# Altium schematic sheet Input_and_MUX.SchDoc
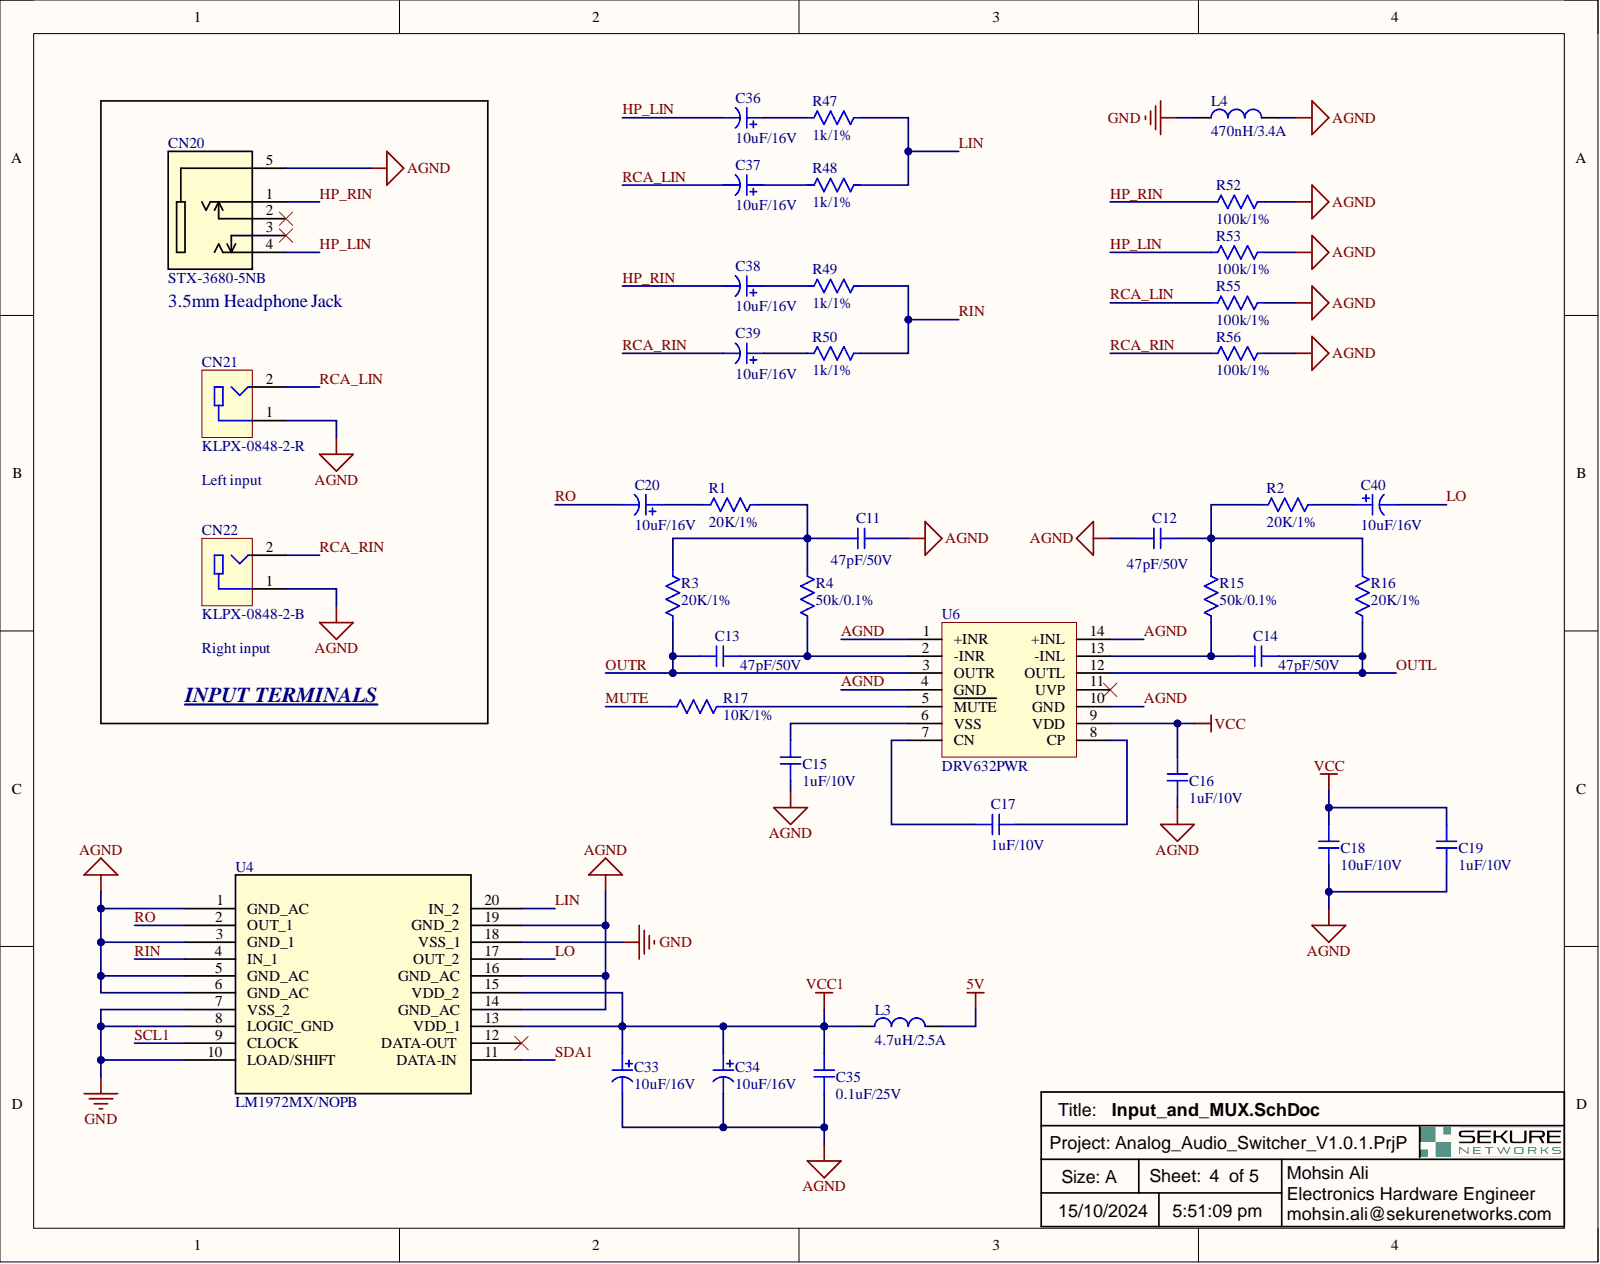
[diagram p0: Input_and_MUX.SchDoc]

## Parts
C11  value 47pF/50V  [CAP 0603]  (hidden comment: Ceramic Capacitor)
C12  value 47pF/50V  [CAP 0603]  (hidden comment: Ceramic Capacitor)
C13  value 47pF/50V  [CAP 0603]  (hidden comment: Ceramic Capacitor)
C14  value 47pF/50V  [CAP 0603]  (hidden comment: Ceramic Capacitor)
C15  value 1uF/10V  [CAP 0603]  (hidden comment: Ceramic Capacitor)
C16  value 1uF/10V  [CAP 0603]  (hidden comment: Ceramic Capacitor)
C17  value 1uF/10V  [CAP 0603]  (hidden comment: Ceramic Capacitor)
C18  value 10uF/10V  [CAP 0603]  (hidden comment: Ceramic Capacitor)
C19  value 1uF/10V  [CAP 0603]  (hidden comment: Ceramic Capacitor)
C20  value 10uF/16V  [CAPAE4643X55M]  (hidden comment: Capacitor)
C33  value 10uF/16V  [CAPAE4643X55M]  (hidden comment: Capacitor)
C34  value 10uF/16V  [CAPAE4643X55M]  (hidden comment: Capacitor)
C35  value 0.1uF/25V  [CAP 0603]  (hidden comment: Ceramic Capacitor)
C36  value 10uF/16V  [CAPAE4643X55M]  (hidden comment: Capacitor)
C37  value 10uF/16V  [CAPAE4643X55M]  (hidden comment: Capacitor)
C38  value 10uF/16V  [CAPAE4643X55M]  (hidden comment: Capacitor)
C39  value 10uF/16V  [CAPAE4643X55M]  (hidden comment: Capacitor)
C40  value 10uF/16V  [CAPAE4643X55M]  (hidden comment: Capacitor)
CN20  value STX-3680-5NB  [STX-3680_KYC]  (hidden comment: Connector)
CN21  value KLPX-0848-2-R  [KLPX-0848-2-R]  (hidden comment: Connector)
CN22  value KLPX-0848-2-B  [KLPX-0848-2-B]  (hidden comment: Connector)
L3  value 4.7uH/2.5A  [B82472G6472M000]  (hidden comment: Inductor)
L4  value 470nH/3.4A  [IND 0806]  (hidden comment: Inductor)
R1  value 20K/1%  [RES 0603]  (hidden comment: Resistor)
R2  value 20K/1%  [RES 0603]  (hidden comment: Resistor)
R3  value 20K/1%  [RES 0603]  (hidden comment: Resistor)
R4  value 50k/0.1%  [RES 0603]  (hidden comment: Resistor)
R15  value 50k/0.1%  [RES 0603]  (hidden comment: Resistor)
R16  value 20K/1%  [RES 0603]  (hidden comment: Resistor)
R17  value 10K/1%  [RES 0603]  (hidden comment: Resistor)
R47  value 1k/1%  [RES 0603]  (hidden comment: Resistor)
R48  value 1k/1%  [RES 0603]  (hidden comment: Resistor)
R49  value 1k/1%  [RES 0603]  (hidden comment: Resistor)
R50  value 1k/1%  [RES 0603]  (hidden comment: Resistor)
R52  value 100k/1%  [RES 0603]  (hidden comment: Resistor)
R53  value 100k/1%  [RES 0603]  (hidden comment: Resistor)
R55  value 100k/1%  [RES 0603]  (hidden comment: Resistor)
R56  value 100k/1%  [RES 0603]  (hidden comment: Resistor)
U4  value LM1972MX/NOPB  [SOIC-20]  (hidden comment: IC)
U6  value DRV632PWR  [14-TSSOP]  (hidden comment: IC)

## Nets
5V: L3.2
GND: L4.1 U4.7(VSS_2) U4.8(LOGIC_GND) U4.10(LOAD/SHIFT) U4.18(VSS_1)
VCC: C16.1 C18.1 C19.1 U6.9(VDD)
VCC1: C33.1 C34.1 C35.1 L3.1 U4.13(VDD_1) U4.15(VDD_2)
AGND: C11.2 C12.1 C15.1 C16.2 C18.2 C19.2 C33.2 C34.2 C35.2 CN20.5 CN21.1 CN22.1 L4.2 R52.2 R53.2 R55.2 R56.2 U4.1(GND_AC) U4.3(GND_1) U4.5(GND_AC) U4.6(GND_AC) U4.14(GND_AC) U4.16(GND_AC) U4.19(GND_2) U6.1(+INR) U6.4(GND) U6.10(GND) U6.14(+INL)
HP_LIN: C36.2 CN20.4 R53.1
HP_RIN: C38.2 CN20.1 R52.1
LIN: R47.1 R48.1 U4.20(IN_2)
LO: C40.2 U4.17(OUT_2)
MUTE: R17.2
OUTL: C14.1 R16.1 U6.12(OUTL)
OUTR: C13.2 R3.1 U6.3(OUTR)
RCA_LIN: C37.2 CN21.2 R55.1
RCA_RIN: C39.2 CN22.2 R56.1
RIN: R49.1 R50.1 U4.4(IN_1)
RO: C20.2 U4.2(OUT_1)
SCL1: U4.9(CLOCK)
SDA1: U4.11(DATA-IN)
NetC11_1: C11.1 R1.1 R3.2 R4.2
NetC12_2: C12.2 R2.1 R15.2 R16.2
NetC13_1: C13.1 R4.1 U6.2(-INR)
NetC14_2: C14.2 R15.1 U6.13(-INL)
NetC15_2: C15.2 U6.6(VSS)
NetC17_1: C17.1 U6.7(CN)
NetC17_2: C17.2 U6.8(CP)
NetC20_1: C20.1 R1.2
NetC36_1: C36.1 R47.2
NetC37_1: C37.1 R48.2
NetC38_1: C38.1 R49.2
NetC39_1: C39.1 R50.2
NetC40_1: C40.1 R2.2
NetR17_1: R17.1 U6.5(MUTE)

Not connected: CN20 2 3; U4 12(DATA-OUT); U6 11(UVP)

## Library data of the parts
C11-C14 = CC0603KRNPO9BN470: 0603 47 pF ±10% Tolerance NP0/C0G Multilayer Ceramic Chip Capacitor; Manufacturer: Yageo; Capacitance: 47pF; Dielectric: C0G; Voltage Rating: 50V; Case Code (Imperial): 0603; Case Code (Metric): 1608; Case/Package: 0603; Category: Ceramic Capacitors; Component Kind: Standard; ComponentLink1Description: https://datasheet.ciiva.com/13264/upy-gp-np0-16v-to-50v-8-3714-13264856.pdf?src-supplier=Mouser; ComponentLink1URL: https://datasheet.ciiva.com/13264/upy-gp-np0-16v-to-50v-8-3714-13264856.pdf?src-supplier=Mouser; Construction: Flat; Depth: 0.8mm; DigiKey: 311-4037-1-ND; Height: 0.8mm; Length: 0.063inch; Library Name: Ceramic Capacitors.IntLib; Max Operating Temperature: 125°C; Min Operating Temperature: -55°C; Mount: Surface Mount; P/N: CC0603KRNPO9BN470; Packaging: Tape and Reel; Pricing 1: 1=0.10000, 10=0.05600, 50=0.03040, 100=0.02480, 500=0.01774, 1000=0.01395 (USD); Radiation Hardening: No; Resistor Type: Ceramic; RoHS: Yes; RoHS Compliant: Yes; Series: CC; Stock 1: 19405; Supplier 1: Digi-Key; Termination: SMD/SMT; Thickness: 0.035inch; Tolerance: 10%; Voltage Rating (DC): 50V; Weight: 7.1E-05oz; Width: 0.031inch
C15-C17 C19 = CL10B105KP8NNNC: Multilayer Ceramic Capacitor, 1 uF, 10 V, ± 10%, X7R, 0603 [1608 Metric]; Manufacturer: Samsung; Material: 4241ConductorMaterial; Voltage Rating: 10V; Case Code (Imperial): 0603; Case Code (Metric): 1608; Case/Package: 0603; Category: Ceramic Capacitors; Component Kind: Standard; Construction: Flat; Depth: 0.8mm; Dielectric: X7R; DigiKey: 1276-1946-1-ND; Height: 0.8mm; Lead Free: Lead Free; Length: 1.6mm; Library Name: Ceramic Capacitors.IntLib; Max Operating Temperature: 125°C; Min Operating Temperature: -55°C; Mounting Technology: Surface Mount; P/N: CL10B105KP8NNNC; Package Quantity: 1; Packaging: Tape and Reel; Pricing: 1=0.1, 10=0.026, 50=0.0146, 100=0.0114, 500=0.00858, 1000=0.00674 (USD); Radiation Hardening: No; RoHS: Yes; RoHS Compliant: Yes; Series: CL; Stock: 7012743; Supplier: Digikey; Thickness: 0.035inch; Tolerance: 10%; Voltage Rating (DC): 10V; Width: 0.031inch
C18 = LMK107BBJ106MALT: CER CAPACITOR 10UF, 10V, 0603,  X5R, REEL, FULL REEL; Manufacturer: Taiyo Yuden; Tolerance: 20%; Capacitance: 10uF; Case Code (Imperial): 0603; Case Code (Metric): 1608; Case/Package: 0603; Category: Ceramic Capacitors; Component Kind: Standard; ComponentLink1Description: https://datasheet.ciiva.com/11596/lmk107bbj106malt-ss-11596989.pdf?src-supplier=Avnet; ComponentLink1URL: https://datasheet.ciiva.com/11596/lmk107bbj106malt-ss-11596989.pdf?src-supplier=Avnet; ComponentLink2Description: https://datasheet.ciiva.com/26872/getdatasheetpartid-637524-26872634.pdf?src-supplier=Verical; ComponentLink2URL: https://datasheet.ciiva.com/26872/getdatasheetpartid-637524-26872634.pdf?src-supplier=Verical; Construction: Flat; Depth: 0.8mm; Dielectric: X5R; DigiKey: 587-3258-1-ND; Height: 0.8mm; Lead Free: Lead Free; Length: 1.6mm; Library Name: Ceramic Capacitors.IntLib; Max Operating Temperature: 85°C; Min Operating Temperature: -55°C; Mount: Surface Mount; P/N: LMK107BBJ106MALT; Package Quantity: 1; Packaging: Tape and Reel; Pricing 1: 1=0.21000, 10=0.14900, 50=0.08460, 100=0.07000, 500=0.04910, 1000=0.04065 (USD); Radiation Hardening: No; REACH SVHC: Unknown; Resistor Type: Ceramic; RoHS: Yes; RoHS Compliant: Yes; Series: M; Stock 1: 589; Supplier 1: Digi-Key; Termination: SMD/SMT; Thickness: 0.035inch; Voltage: 10V; Voltage Rating: 10V; Voltage Rating (DC): 10V; Width: 0.8mm
C20 C33 C34 C36-C40 = 865080340001: Cap, 10ΜF, 16V, SMD; Manufacturer: Wurth Electronics; Capacitance: 10uF; Impedance: 2.2R; Life (Hours): 2000Hour; Polarity: Polar; Ripple Current: 80mA; Tolerance: 20%; Case/Package: Radial; Category: Aluminum Electrolytic Capacitors; Component Kind: Standard; ComponentLink1Description: http://www.farnell.com/datasheets/1880118.pdf?src-supplier=Farnell; ComponentLink1URL: http://www.farnell.com/datasheets/1880118.pdf?src-supplier=Farnell; ComponentLink2Description: http://www.farnell.com/datasheets/1880118.pdf?src-supplier=Element14; ComponentLink2URL: http://www.farnell.com/datasheets/1880118.pdf?src-supplier=Element14; ComponentLink3Description: http://www.farnell.com/datasheets/1880118.pdf?src-supplier=Newark; ComponentLink3URL: http://www.farnell.com/datasheets/1880118.pdf?src-supplier=Newark; ComponentLink4Description: https://katalog.we-online.de/pbs/datasheet/865080340001.pdf?src-supplier=Digi-Key; ComponentLink4URL: https://katalog.we-online.de/pbs/datasheet/865080340001.pdf?src-supplier=Digi-Key; Diameter: 4mm; DigiKey: 732-8411-1-ND; Height: 5.5mm; Height - Seated (Max): 0.217inch; Lead Free: Lead Free; Library Name: Radial Capacitors.IntLib; Max Operating Temperature: 105°C; Min Operating Temperature: -55°C; Mount: Surface Mount; P/N: 865080340001; Package Quantity: 2000; Packaging: Cut Tape; Pricing 1: 1=0.18000, 50=0.15100, 100=0.13100, 250=0.11700, 500=0.10200, 1000=0.09700 (USD); REACH SVHC: Unknown; Ripple Current (AC): 80mA; RoHS: Yes; RoHS Compliant: Yes; Stock 1: 11596; Supplier 1: Digi-Key; Voltage Rating: 16V; Voltage Rating (DC): 16V
C35 = CL10B104KA8NNNC: Multilayer Ceramic Capacitor, 0.1 uF, 25 V, ± 10%, X7R, 0603 [1608 Metric]; Manufacturer: Samsung; Material: 4241ConductorMaterial; Voltage: 25V; Case Code (Imperial): 0603; Case Code (Metric): 1608; Case/Package: 0603; Category: Ceramic Capacitors; Component Kind: Standard; ComponentLink1Description: https://datasheet.ciiva.com/25805/cl10b104ka8nnnc-25805120.pdf?src-supplier=Digikey; ComponentLink1URL: https://datasheet.ciiva.com/25805/cl10b104ka8nnnc-25805120.pdf?src-supplier=Digikey; ComponentLink2Description: https://datasheet.ciiva.com/26838/getdatasheetpartid-354050-26838409.pdf?src-supplier=Verical; ComponentLink2URL: https://datasheet.ciiva.com/26838/getdatasheetpartid-354050-26838409.pdf?src-supplier=Verical; Depth: 0.8mm; Dielectric: X7R; DigiKey: 1276-1006-1-ND; Height: 0.8mm; Lead Free: Lead Free; Length: 1.6mm; Library Name: Ceramic Capacitors.IntLib; Max Operating Temperature: 125°C; Min Operating Temperature: -55°C; Mounting Technology: Surface Mount; P/N: CL10B104KA8NNNC; Package Quantity: 1; Packaging: Tape and Reel; Pricing: 1=0.1, 10=0.024, 50=0.0136, 100=0.0105, 500=0.00792, 1000=0.00622 (USD); Radiation Hardening: No; RoHS: Yes; RoHS Compliant: Yes; Series: CL; Stock: 708971; Supplier: Digikey; Thickness: 0.035inch; Tolerance: 10%; Voltage Rating: 25V; Voltage Rating (DC): 25V; Width: 0.031inch
CN20 = STX-3680-5NB: Conn Audio F 5 POS Solder ST Thru-Hole 5 Terminal 1 Port; Manufacturer: Kycon; Body Color: Black; Gender: Female; Number of Contacts: 5; Shielding: Unshielded; Voltage Rating: 16V; Category: Connectors; Color: Black; Component Kind: Standard; ComponentLink1Description: http://www.mouser.com/ds/2/222/STX-3680-334630.pdf?src-supplier=Mouser; ComponentLink1URL: http://www.mouser.com/ds/2/222/STX-3680-334630.pdf?src-supplier=Mouser; ComponentLink2Description: http://www.kycon.com/Pub_Eng_Draw/STX-3680-5NB.pdf?src-supplier=Digikey; ComponentLink2URL: http://www.kycon.com/Pub_Eng_Draw/STX-3680-5NB.pdf?src-supplier=Digikey; ComponentLink3Description: https://datasheet.octopart.com/STX-3680-5NB-Kycon-datasheet-137322849.pdf?src-supplier=Sager+Electronics; ComponentLink3URL: https://datasheet.octopart.com/STX-3680-5NB-Kycon-datasheet-137322849.pdf?src-supplier=Sager+Electronics; Contact Material: Copper Alloy; Contact Plating: Silver; DigiKey: 2092-STX-3680-5NB-ND; Housing Material: Thermoplastic; Library Name: Connectors.IntLib; Max Operating Temperature: 85°C; Min Operating Temperature: -25°C; Mounting Technology: Through Hole; Orientation: Vertical; P/N: STX-3680-5NB; Package Quantity: 180; Packaging: Tray; Pricing: 1=1.81, 10=1.576, 25=1.5088, 40=1.4755, 190=1.40837, 380=1.27421, 570=1.17361, 950=1.00596, 2470=0.93889 (USD); Radiation Hardening: No; RoHS: Yes; RoHS Compliant: Yes; Series: STX-3680; Stock: 2747; Supplier: Digikey; Termination: Solder
CN21 = KLPX-0848-2-R: RCA Phono Connectors PCB VERTICAL JACK RED; Manufacturer: Kycon; Category: Connectors; Component Kind: Standard; ComponentLink1Description: http://www.mouser.com/ds/2/222/KLPX-334830.pdf?src-supplier=Mouser; ComponentLink1URL: http://www.mouser.com/ds/2/222/KLPX-334830.pdf?src-supplier=Mouser; ComponentLink2Description: http://domino2.kycon.com/catalog_PDF/Standard%20Catalog%20page/RCA%20Phono%20Jacks/SKLPX.pdf?src-supplier=Avnet; ComponentLink2URL: http://domino2.kycon.com/catalog_PDF/Standard%20Catalog%20page/RCA%20Phono%20Jacks/SKLPX.pdf?src-supplier=Avnet; ComponentLink3Description: http://www.kycon.com/2013Catalogpage/RCA/KLPX.pdf?src-supplier=Digikey; ComponentLink3URL: http://www.kycon.com/2013Catalogpage/RCA/KLPX.pdf?src-supplier=Digikey; ComponentLink4Description: https://datasheet.octopart.com/KLPX-0848-2-R-Kycon-datasheet-116534117.pdf?src-supplier=Toby+Electronics; ComponentLink4URL: https://datasheet.octopart.com/KLPX-0848-2-R-Kycon-datasheet-116534117.pdf?src-supplier=Toby+Electronics; DigiKey: 2092-KLPX-0848-2-R-ND; Library Name: Connectors.SchLib; P/N: KLPX-0848-2-R; Pricing: 1=1.16, 10=0.885, 25=0.7956, 50=0.7348, 100=0.6795, 250=0.61388, 500=0.5695, 1000=0.52924, 2500=0.48174 (USD); RoHS: Yes; Stock: 615; Supplier: Digikey
CN22 = KLPX-0848-2-B: RCA Phono Connectors PCB VERTICAL JACK BLACK; Manufacturer: Kycon; Category: Connectors; Component Kind: Standard; ComponentLink1Description: http://www.mouser.com/ds/2/222/KLPX-334830.pdf?src-supplier=Mouser; ComponentLink1URL: http://www.mouser.com/ds/2/222/KLPX-334830.pdf?src-supplier=Mouser; ComponentLink2Description: http://domino2.kycon.com/catalog_PDF/Standard%20Catalog%20page/RCA%20Phono%20Jacks/SKLPX.pdf?src-supplier=Avnet; ComponentLink2URL: http://domino2.kycon.com/catalog_PDF/Standard%20Catalog%20page/RCA%20Phono%20Jacks/SKLPX.pdf?src-supplier=Avnet; ComponentLink3Description: http://www.kycon.com/2013Catalogpage/RCA/KLPX.pdf?src-supplier=Digikey; ComponentLink3URL: http://www.kycon.com/2013Catalogpage/RCA/KLPX.pdf?src-supplier=Digikey; ComponentLink4Description: https://datasheet.octopart.com/KLPX-0848-2-B-Kycon-datasheet-116534117.pdf?src-supplier=Toby+Electronics; ComponentLink4URL: https://datasheet.octopart.com/KLPX-0848-2-B-Kycon-datasheet-116534117.pdf?src-supplier=Toby+Electronics; DigiKey: 2092-KLPX-0848-2-B-ND; Library Name: Connectors.IntLib; P/N: KLPX-0848-2-B; Pricing: 1=0.98, 10=0.826, 25=0.7928, 50=0.7758, 100=0.7421, 250=0.67468, 500=0.60722, 1000=0.53974, 2500=0.48914 (USD); RoHS: Yes; Stock: 3475; Supplier: Digikey
L3 = B82472G6472M000: Ind Power Shielded 4.7uH 20% 100KHz Ferrite 2.5A Blister T/R; Manufacturer: EPCOS - TDK Electronics; Component Kind: Standard; DigiKey: 495-77197-2-ND; Library Name: Inductors_NEW.IntLib; P/N: B82472G6472M000
L4 = 1286AS-H-R47M=P2: Inductor Power Shielded Wirewound 0.47uH 20% 1MHz Metal 3.2A 0.052Ohm DCR 0806 T/R; Manufacturer: Murata; Core Material: Ferrite; Current Rating: 3.4A; DC Resistance (DCR): 52mR; Max DC Current: 3.8A; Case Code (Imperial): 0806; Case Code (Metric): 2016; Case/Package: 0806; Category: Fixed Inductors; Component Kind: Standard; ComponentLink1Description: http://www.farnell.com/datasheets/2021974.pdf?src-supplier=Farnell; ComponentLink1URL: http://www.farnell.com/datasheets/2021974.pdf?src-supplier=Farnell; ComponentLink2Description: http://www.toko.co.jp/products/pdf/inductors/dfe201612c.pdf?src-supplier=Avnet; ComponentLink2URL: http://www.toko.co.jp/products/pdf/inductors/dfe201612c.pdf?src-supplier=Avnet; ComponentLink3Description: http://search.murata.co.jp/Ceramy/image/img/P02/J(E)TE243A-0010_DFE201612C_reference.pdf?src-supplier=Digikey; ComponentLink3URL: http://search.murata.co.jp/Ceramy/image/img/P02/J(E)TE243A-0010_DFE201612C_reference.pdf?src-supplier=Digikey; ComponentLink4Description: http://www.toko.co.jp/products/pdf/inductors/dfe201612c.pdf?src-supplier=Digikey; ComponentLink4URL: http://www.toko.co.jp/products/pdf/inductors/dfe201612c.pdf?src-supplier=Digikey; Depth: 1.6mm; DigiKey: 490-10606-1-ND; Height - Seated (Max): 0.047inch; Length: 0.079inch; Library Name: Inductors_NEW.IntLib; Max Operating Temperature: 85°C; Min Operating Temperature: -40°C; Mounting Technology: Surface Mount; P/N: 1286AS-H-R47M=P2; Packaging: Cut Tape; Pricing: 1=0.34, 10=0.264, 25=0.2388, 50=0.2214, 100=0.2051, 250=0.18544, 500=0.17182, 1000=0.15914 (USD); RoHS: Yes; RoHS Compliant: Yes; Series: DFE201612C; Shielding: Shielded; Stock: 11624; Supplier: Digikey; Test Frequency: 1MHz; Tolerance: 20%; Width: 0.063inch
R1-R3 R16 = CRCW060320K0FKEA: RES SMD 20 kohm,  0603, 75 V, Thick Film, 100 mW; Manufacturer: Vishay; Power Rating: 100mW; Resistance: 20kR; Temperature Coefficient: 100ppm/°C; Tolerance: 1%; Voltage Rating: 75V; Case Code (Imperial): 0603; Case Code (Metric): 1608; Case/Package: 0603; Component Kind: Standard; ComponentLink1Description: https://datasheet.ciiva.com/3257/dcrcwe3-3257238.pdf?src-supplier=Avnet; ComponentLink1URL: https://datasheet.ciiva.com/3257/dcrcwe3-3257238.pdf?src-supplier=Avnet; ComponentLink2Description: https://datasheet.ciiva.com/7841/1697337-7841574.pdf?src-supplier=Element14; ComponentLink2URL: https://datasheet.ciiva.com/7841/1697337-7841574.pdf?src-supplier=Element14; ComponentLink3Description: https://datasheet.ciiva.com/3257/dcrcwe3-3257239.pdf?src-supplier=Avnet; ComponentLink3URL: https://datasheet.ciiva.com/3257/dcrcwe3-3257239.pdf?src-supplier=Avnet; ComponentLink4Description: https://datasheet.ciiva.com/16351/dcrcwe3-16351112.pdf?src-supplier=Future+Electronics; ComponentLink4URL: https://datasheet.ciiva.com/16351/dcrcwe3-16351112.pdf?src-supplier=Future+Electronics; ComponentLink5Description: https://datasheet.ciiva.com/26314/fld-crcw-e3-data-en-26314665.pdf?src-supplier=Distrelec; ComponentLink5URL: https://datasheet.ciiva.com/26314/fld-crcw-e3-data-en-26314665.pdf?src-supplier=Distrelec; ComponentLink6Description: https://datasheet.ciiva.com/5549/dcrcwe3-109170-5549626.pdf?src-supplier=Mouser; ComponentLink6URL: https://datasheet.ciiva.com/5549/dcrcwe3-109170-5549626.pdf?src-supplier=Mouser; ComponentLink7Description: https://datasheet.ciiva.com/16893/dcrcw-16893852.pdf?src-supplier=Avnet+Europe; ComponentLink7URL: https://datasheet.ciiva.com/16893/dcrcw-16893852.pdf?src-supplier=Avnet+Europe; Composition: Thick Film; Contact Plating: Tin,Lead; Depth: 0.85mm; DigiKey: 541-20.0KHCT-ND; Height: 0.45mm; Lead Free: Lead Free; Length: 1.55mm; Library Name: Resistors.IntLib; Max Operating Temperature: 155°C; Military Standard: Not; Min Operating Temperature: -55°C; Mount: Surface Mount; Number of Pins: 2; P/N: CRCW060320K0FKEA; Package Quantity: 5000; Packaging: Tape and Reel; Pricing 1: 1=0.10000, 10=0.03400, 100=0.01390, 1000=0.00623, 2500=0.00540 (USD); Radiation Hardening: No; REACH SVHC: Unknown; RoHS: Yes; Stock 1: 24897; Supplier 1: Digi-Key; Termination: SMD/SMT; Voltage Rating (DC): 75V; Weight: 7.1E-05oz; Width: 0.85mm
R4 R15 = RT0603BRD0750KL: Fixed Resistor, Thin Film, 0.1W, 50000ohm, 75V, 0.1% +/-Tol, 25ppm/Cel, Surface Mount, 0603; Manufacturer: Yageo; Max Power Dissipation: 100mW; Temperature Coefficient: 25ppm/°C; Case/Package: 0603; Category: Chip SMD Resistors; Component Kind: Standard; ComponentLink1Description: https://www.yageo.com/upload/media/product/productsearch/datasheet/rchip/PYu-RT_1-to-0.01_RoHS_L_12.pdf?src-supplier=Digikey; ComponentLink1URL: https://www.yageo.com/upload/media/product/productsearch/datasheet/rchip/PYu-RT_1-to-0.01_RoHS_L_12.pdf?src-supplier=Digikey; ComponentLink2Description: https://datasheet.octopart.com/RT0603BRD0750KL-Yageo-datasheet-169971040.pdf?src-supplier=Future+Electronics; ComponentLink2URL: https://datasheet.octopart.com/RT0603BRD0750KL-Yageo-datasheet-169971040.pdf?src-supplier=Future+Electronics; ComponentLink3Description: https://datasheet.octopart.com/RT0603BRD0750KL-Yageo-datasheet-164439670.pdf?src-supplier=Newark; ComponentLink3URL: https://datasheet.octopart.com/RT0603BRD0750KL-Yageo-datasheet-164439670.pdf?src-supplier=Newark; DigiKey: 13-RT0603BRD0750KLCT-ND; Height: 550um; Library Name: Resistors.IntLib; Max Operating Temperature: 155°C; Min Operating Temperature: -55°C; Number of Terminations: 2; P/N: RT0603BRD0750KL; Packaging: Tape and Reel; Power Rating: 100mW; Pricing: 1=0.14, 10=0.104, 50=0.0822, 100=0.0737, 500=0.05612, 1000=0.04959 (USD); RoHS: No; Series: RT; Stock: 19778; Supplier: Digikey; Tolerance: 0.1%; Voltage Rating: 75V
R17 = RC0603FR-0710KL: RES SMD 10K OHM 1% 1/10W 0603; Manufacturer: Yageo; Power Rating: 100mW; Resistance: 10kR; Temperature Coefficient: 100ppm/°C; Voltage Rating: 75V; Voltage Rating (DC): 50V; Case Code (Imperial): 0603; Case Code (Metric): 1608; Case/Package: 0603; Component Kind: Standard; ComponentLink1Description: https://datasheet.ciiva.com/7660/38590-7660789.pdf?src-supplier=Element14; ComponentLink1URL: https://datasheet.ciiva.com/7660/38590-7660789.pdf?src-supplier=Element14; ComponentLink2Description: https://datasheet.ciiva.com/15189/getdatasheetpartid-409289-15189591.pdf?src-supplier=Verical; ComponentLink2URL: https://datasheet.ciiva.com/15189/getdatasheetpartid-409289-15189591.pdf?src-supplier=Verical; ComponentLink3Description: https://datasheet.ciiva.com/46440/1868787-46440891.pdf?src-supplier=Element14; ComponentLink3URL: https://datasheet.ciiva.com/46440/1868787-46440891.pdf?src-supplier=Element14; ComponentLink4Description: https://datasheet.ciiva.com/7983/443971-7983596.pdf?src-supplier=Element14; ComponentLink4URL: https://datasheet.ciiva.com/7983/443971-7983596.pdf?src-supplier=Element14; ComponentLink5Description: https://datasheet.ciiva.com/7746/1738695-7746239.pdf?src-supplier=Element14; ComponentLink5URL: https://datasheet.ciiva.com/7746/1738695-7746239.pdf?src-supplier=Element14; ComponentLink6Description: https://datasheet.ciiva.com/22747/443971-22747519.pdf?src-supplier=Element14; ComponentLink6URL: https://datasheet.ciiva.com/22747/443971-22747519.pdf?src-supplier=Element14; Composition: Thick Film; Depth: 0.8mm; DigiKey: 311-10.0KHRDKR-ND; Height: 0.45mm; Lead Free: Lead Free; Lead Pitch: 0.3mm; Length: 1.6mm; Library Name: Resistors.IntLib; Max Operating Temperature: 155°C; Military Standard: Not; Min Operating Temperature: -55°C; Number of Pins: 2; P/N: RC0603FR-0710KL; Packaging: Tape and Reel; Pricing 1: 1=0.10000, 10=0.02300, 100=0.00940, 1000=0.00421, 2500=0.00366 (USD); REACH SVHC: No SVHC; RoHS: Yes; Stock 1: 55833360; Supplier 1: Digi-Key; Termination: SMD/SMT; Tolerance: 1%; Weight: 7.1E-05oz; Width: 0.8mm
R47-R50 = CRCW06031K00FKEA: RES SMD 1 kohm 1% Thick Film, 100 mW; Manufacturer: Vishay Dale; Max Power Dissipation: 100mW; Resistance: 1kR; Temperature Coefficient: 100ppm/°C; Voltage Rating: 75V; Case Code (Imperial): 0603; Case Code (Metric): 1608; Case/Package: 0603; Component Kind: Standard; ComponentLink1Description: https://datasheet.ciiva.com/11808/0900766b80d98afc-11808625.pdf?src-supplier=RSComponents; ComponentLink1URL: https://datasheet.ciiva.com/11808/0900766b80d98afc-11808625.pdf?src-supplier=RSComponents; ComponentLink2Description: https://datasheet.ciiva.com/2465/dcrcwe3-2465536.pdf?src-supplier=Digi-Key; ComponentLink2URL: https://datasheet.ciiva.com/2465/dcrcwe3-2465536.pdf?src-supplier=Digi-Key; ComponentLink3Description: https://datasheet.ciiva.com/8336/dcrcwe3-8336822.pdf?src-supplier=Avnet; ComponentLink3URL: https://datasheet.ciiva.com/8336/dcrcwe3-8336822.pdf?src-supplier=Avnet; ComponentLink4Description: https://datasheet.ciiva.com/16515/dcrcwe3-16515078.pdf?src-supplier=Avnet+Asia; ComponentLink4URL: https://datasheet.ciiva.com/16515/dcrcwe3-16515078.pdf?src-supplier=Avnet+Asia; ComponentLink5Description: https://datasheet.ciiva.com/46441/1720486-46441622.pdf?src-supplier=Element14; ComponentLink5URL: https://datasheet.ciiva.com/46441/1720486-46441622.pdf?src-supplier=Element14; ComponentLink6Description: https://datasheet.ciiva.com/26316/fld-crcw-e3-data-en-26316551.pdf?src-supplier=Distrelec; ComponentLink6URL: https://datasheet.ciiva.com/26316/fld-crcw-e3-data-en-26316551.pdf?src-supplier=Distrelec; ComponentLink7Description: https://datasheet.ciiva.com/46441/1720486-46441623.pdf?src-supplier=Element14; ComponentLink7URL: https://datasheet.ciiva.com/46441/1720486-46441623.pdf?src-supplier=Element14; ComponentLink8Description: https://datasheet.ciiva.com/46385/1697337-46385497.pdf?src-supplier=Element14; ComponentLink8URL: https://datasheet.ciiva.com/46385/1697337-46385497.pdf?src-supplier=Element14; ComponentLink9Description: https://datasheet.ciiva.com/3257/dcrcwe3-3257132.pdf?src-supplier=Avnet; ComponentLink9URL: https://datasheet.ciiva.com/3257/dcrcwe3-3257132.pdf?src-supplier=Avnet; ComponentLink10Description: https://datasheet.ciiva.com/16199/dcrcwe3-16199053.pdf?src-supplier=Future+Electronics; ComponentLink10URL: https://datasheet.ciiva.com/16199/dcrcwe3-16199053.pdf?src-supplier=Future+Electronics; ComponentLink11Description: https://datasheet.ciiva.com/2465/dcrcwe3-2465534.pdf?src-supplier=Digi-Key; ComponentLink11URL: https://datasheet.ciiva.com/2465/dcrcwe3-2465534.pdf?src-supplier=Digi-Key; ComponentLink12Description: https://datasheet.ciiva.com/16893/dcrcwe3-16893742.pdf?src-supplier=Avnet+Europe; ComponentLink12URL: https://datasheet.ciiva.com/16893/dcrcwe3-16893742.pdf?src-supplier=Avnet+Europe; ComponentLink13Description: https://datasheet.ciiva.com/2465/dcrcwe3-2465535.pdf?src-supplier=Digi-Key; ComponentLink13URL: https://datasheet.ciiva.com/2465/dcrcwe3-2465535.pdf?src-supplier=Digi-Key; Composition: Thick Film; Contact Plating: Tin; Depth: 0.85mm; DigiKey: 541-1.00KHCT-ND; Features: Automotive AEC-Q200; Height: 0.45mm; Lead Free: Lead Free; Length: 1.55mm; Library Name: Resistors.IntLib; Max Operating Temperature: 155°C; Military Standard: Not; Min Operating Temperature: -55°C; Mount: Surface Mount; Number of Pins: 2; Number of Terminations: 2; P/N: CRCW06031K00FKEA; Package Quantity: 10; Packaging: Tape and Reel; Power Rating: 100mW; Pricing 1: 1=0.10000, 10=0.03400, 100=0.01390, 1000=0.00623, 2500=0.00540 (USD); Radiation Hardening: No; REACH SVHC: Unknown; RoHS: Yes; Stock 1: 1070064; Supplier 1: Digi-Key; Termination: SMD/SMT; Tolerance: 1%; Voltage Rating (DC): 75V; Weight: 7.1E-05oz; Width: 0.033inch
R52 R53 R55 R56 = RC0603FR-07100KL: RES SMD 100K Ohm 1% 0603; Manufacturer: Yageo; Power Rating: 100mW; Resistance: 100kR; Temperature Coefficient: 100ppm/°C; Voltage Rating: 50V; Working Voltage: 75V; Case Code (Imperial): 0603; Case Code (Metric): 1608; Case/Package: 0603; Component Kind: Standard; ComponentLink1Description: https://datasheet.ciiva.com/11808/0900766b80f96399-11808107.pdf?src-supplier=RSComponents; ComponentLink1URL: https://datasheet.ciiva.com/11808/0900766b80f96399-11808107.pdf?src-supplier=RSComponents; ComponentLink2Description: https://datasheet.ciiva.com/46440/1868787-46440889.pdf?src-supplier=Element14; ComponentLink2URL: https://datasheet.ciiva.com/46440/1868787-46440889.pdf?src-supplier=Element14; ComponentLink3Description: https://datasheet.ciiva.com/7746/1738695-7746235.pdf?src-supplier=Element14; ComponentLink3URL: https://datasheet.ciiva.com/7746/1738695-7746235.pdf?src-supplier=Element14; ComponentLink4Description: https://datasheet.ciiva.com/7983/443971-7983593.pdf?src-supplier=Element14; ComponentLink4URL: https://datasheet.ciiva.com/7983/443971-7983593.pdf?src-supplier=Element14; ComponentLink5Description: https://datasheet.ciiva.com/7746/1738695-7746234.pdf?src-supplier=Element14; ComponentLink5URL: https://datasheet.ciiva.com/7746/1738695-7746234.pdf?src-supplier=Element14; ComponentLink6Description: https://datasheet.ciiva.com/22747/443971-22747516.pdf?src-supplier=Element14; ComponentLink6URL: https://datasheet.ciiva.com/22747/443971-22747516.pdf?src-supplier=Element14; Composition: Thick Film; Depth: 0.8mm; DigiKey: 311-100KHRCT-ND; Height: 0.45mm; Lead Free: Lead Free; Length: 1.6mm; Library Name: Resistors.IntLib; Max Operating Temperature: 155°C; Military Standard: Not; Min Operating Temperature: -55°C; Number of Pins: 2; Number of Terminations: 2; P/N: RC0603FR-07100KL; Packaging: Tape and Reel; Pricing 1: 1=0.10000, 10=0.02300, 100=0.00940, 1000=0.00421, 2500=0.00366 (USD); REACH SVHC: No SVHC; RoHS: Yes; Stock 1: 34090397; Supplier 1: Digi-Key; Termination: SMD/SMT; Tolerance: 1%; Voltage Rating (DC): 50V; Width: 0.8mm
U4 = LM1972MX/NOPB: μPot 2-channel 78 db audio attenuator with mute 20-SOIC 0 to 70; Manufacturer: TI National Semiconductor; Gain: 78dB; Interface: SPI; Number of Bits: 16; Number of Channels: 2; Operating Supply Voltage: 12V; Power Dissipation: 150mW; Supply Current: 4mA; Case/Package: SOIC; Category: Amplifiers - Audio; Component Kind: Standard; ComponentLink1Description: https://datasheet.ciiva.com/3268/lm1972-3268754.pdf?src-supplier=Avnet; ComponentLink1URL: https://datasheet.ciiva.com/3268/lm1972-3268754.pdf?src-supplier=Avnet; ComponentLink2Description: https://datasheet.ciiva.com/6625/lm1972-6625386.pdf?src-supplier=Digikey; ComponentLink2URL: https://datasheet.ciiva.com/6625/lm1972-6625386.pdf?src-supplier=Digikey; ComponentLink3Description: https://datasheet.ciiva.com/6625/lm1972-6625387.pdf?src-supplier=Digikey; ComponentLink3URL: https://datasheet.ciiva.com/6625/lm1972-6625387.pdf?src-supplier=Digikey; ComponentLink4Description: https://datasheet.ciiva.com/6625/lm1972-6625388.pdf?src-supplier=Digikey; ComponentLink4URL: https://datasheet.ciiva.com/6625/lm1972-6625388.pdf?src-supplier=Digikey; DigiKey: LM1972MX/NOPBCT-ND; Lead Free: Lead Free; Library Name: IC's.IntLib; Max Operating Temperature: 70°C; Min Operating Temperature: 0°C; Mounting Technology: Surface Mount; P/N: LM1972MX/NOPB; Packaging: Tape and Reel; Pins: 20; Pricing: 1=4.37, 10=3.198, 25=2.904, 100=2.5803, 250=2.42592, 500=2.33284 (USD); Radiation Hardening: No; RoHS: Yes; RoHS Compliant: Yes; Series: -; Stock: 2646; Supplier: Digikey
U6 = DRV632PWR: Consumer Circuit, PDSO14; Manufacturer: Texas Instruments; Channels: 1; Max Supply Voltage: 3.6V; Min Supply Voltage: 3V; Package Body Material: Plastic; Qualification Status: Not Qualified; Case/Package: TSSOP; Category: Amplifiers - Audio; Component Kind: Standard; ComponentLink1Description: https://www.ti.com:443/lit/ds/symlink/drv632.pdf?src-supplier=IHS+Markit; ComponentLink1URL: https://www.ti.com:443/lit/ds/symlink/drv632.pdf?src-supplier=IHS+Markit; ComponentLink2Description: https://datasheet.ciiva.com/1157/drv632-1157351.pdf?src-supplier=Newark; ComponentLink2URL: https://datasheet.ciiva.com/1157/drv632-1157351.pdf?src-supplier=Newark; ComponentLink3Description: https://datasheet.ciiva.com/1825/drv632-1825796.pdf?src-supplier=Digikey; ComponentLink3URL: https://datasheet.ciiva.com/1825/drv632-1825796.pdf?src-supplier=Digikey; ComponentLink4Description: https://datasheet.ciiva.com/15132/getdatasheetpartid-302175-15132609.pdf?src-supplier=Verical; ComponentLink4URL: https://datasheet.ciiva.com/15132/getdatasheetpartid-302175-15132609.pdf?src-supplier=Verical; ComponentLink5Description: https://datasheet.ciiva.com/2965/drv632-2965593.pdf?src-supplier=Avnet; ComponentLink5URL: https://datasheet.ciiva.com/2965/drv632-2965593.pdf?src-supplier=Avnet; ComponentLink6Description: https://datasheet.ciiva.com/1825/drv632-1825797.pdf?src-supplier=Digikey; ComponentLink6URL: https://datasheet.ciiva.com/1825/drv632-1825797.pdf?src-supplier=Digikey; ComponentLink7Description: https://datasheet.ciiva.com/1825/drv632-1825798.pdf?src-supplier=Digikey; ComponentLink7URL: https://datasheet.ciiva.com/1825/drv632-1825798.pdf?src-supplier=Digikey; ComponentLink8Description: https://datasheet.ciiva.com/48711/001072922-da-01-en-ic-line-dvr-ster-drv632pwr-tssop-14-tid-48711403.pdf?src-supplier=Conrad; ComponentLink8URL: https://datasheet.ciiva.com/48711/001072922-da-01-en-ic-line-dvr-ster-drv632pwr-tssop-14-tid-48711403.pdf?src-supplier=Conrad; Consumer IC Type: CONSUMER CIRCUIT; DigiKey: 296-28191-1-ND; Height - Seated (Max): 1.2mm; JESD-30 Code: R-PDSO-G14; JESD-609 Code: e4; Length: 5mm; Library Name: IC's.IntLib; Max Operating Temperature: 85°C; Min Operating Temperature: -40°C; Moisture Sensitivity Level: 2; P/N: DRV632PWR; Package Shape: Rectangular; Package Style: SMALL OUTLINE, THIN PROFILE, SHRINK PITCHMeter; Peak Reflow Temperature (Cel): 260°C; Pins: 14; Pricing: 1=0.69, 10=0.474, 25=0.4192, 100=0.3587, 250=0.32996, 500=0.31262, 1000=0.29833 (USD); Reach SVHC Compliant: Yes; RoHS: Yes; RoHS Compliant: Yes; Stock: 4668; Supplier: Digikey; Surface Mount: Yes; Temperature Grade: INDUSTRIAL; Terminal Finish: Nickel; Terminal Form: Gull Wing; Terminal Pitch: 0.65mm; Terminal Position: DUAL; Width: 4.4mm
